# Altium schematic sheet Sheet3.SchDoc
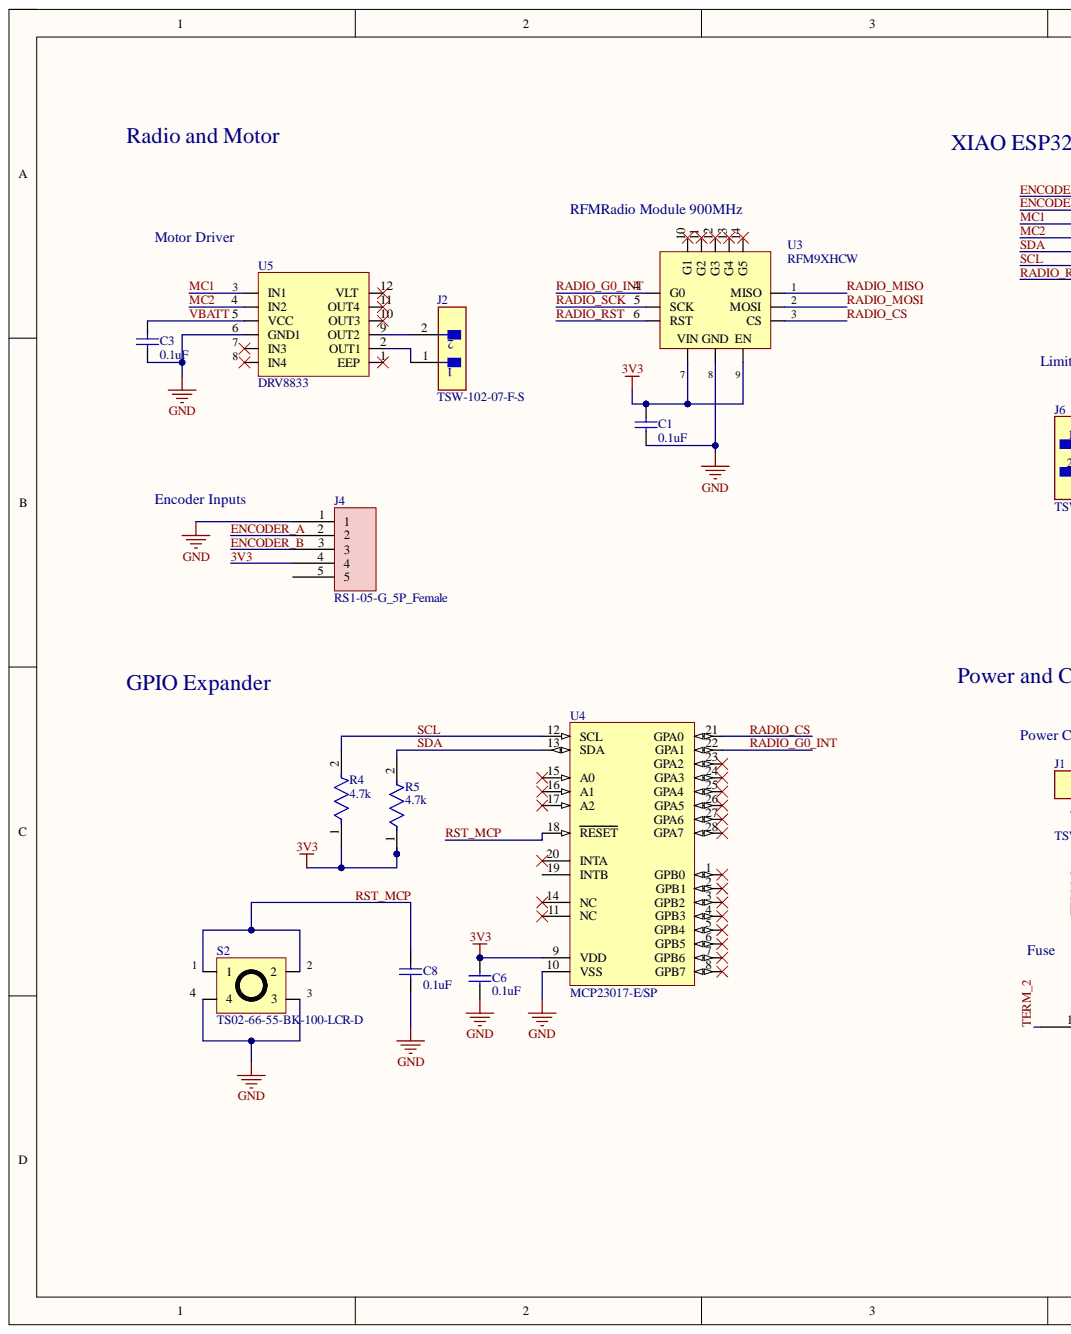
[diagram p0: Sheet3.SchDoc, left]
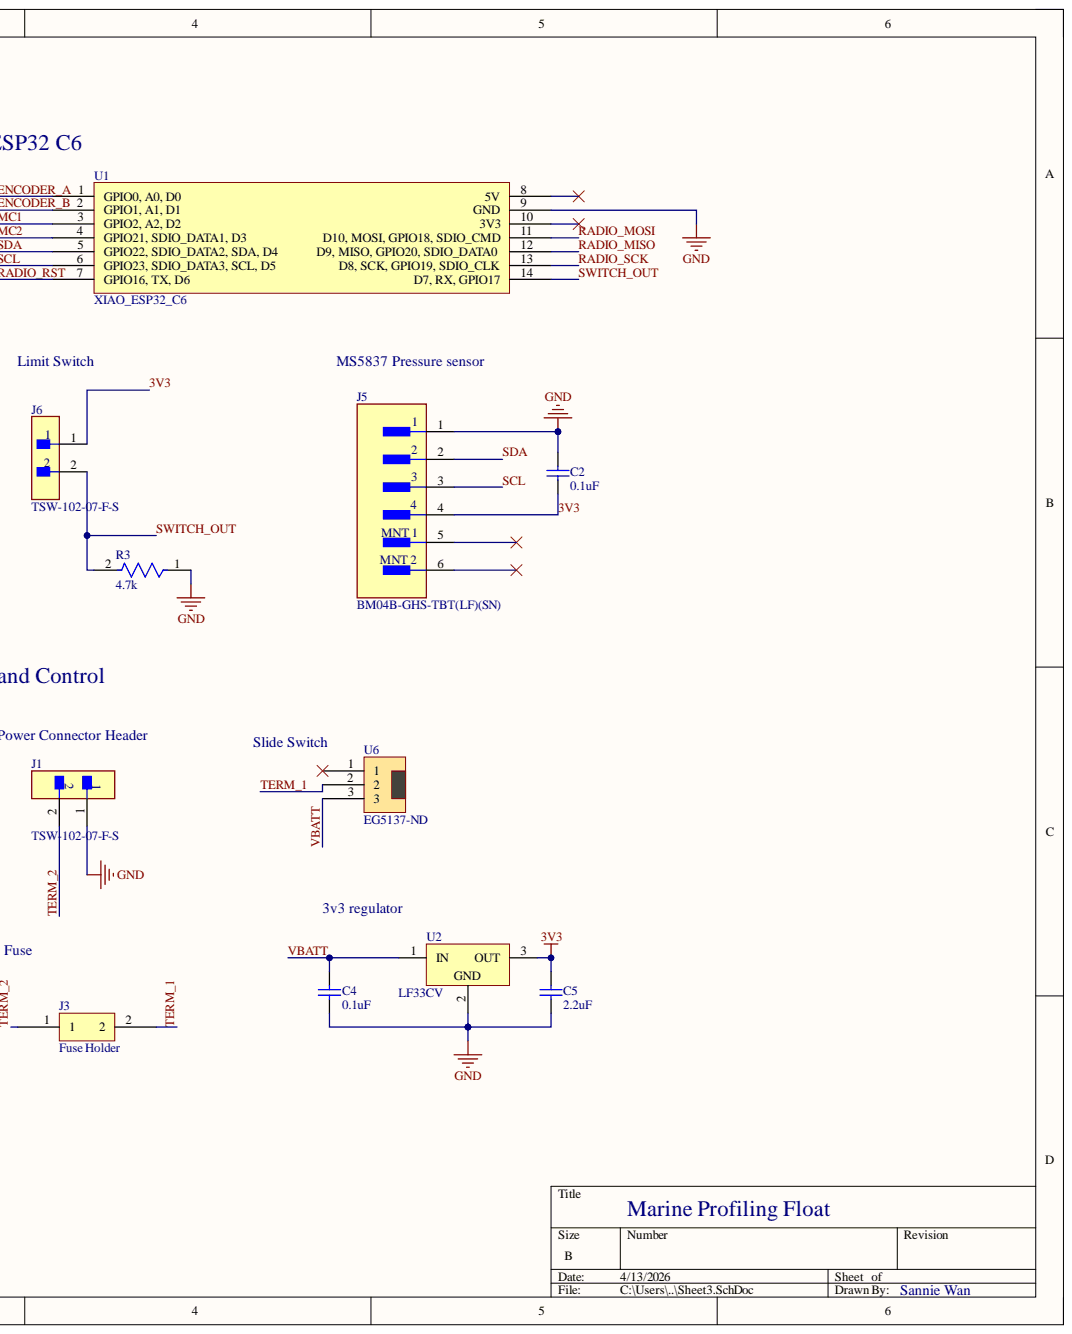
[diagram p1: Sheet3.SchDoc, right]

## Parts
C1  0.1uF  [CAPC0805(2012)100_M]
C2  0.1uF  [CAPC0805(2012)100_M]
C3  0.1uF  [CAPC0805(2012)100_M]
C4  0.1uF  [CAPC0805(2012)100_M]
C5  2.2uF  [CAPC2013X152X50ML20T25]
C6  0.1uF  [CAPC0805(2012)100_M]
C8  0.1uF  [CAPC0805(2012)100_M]
J1  TSW-102-07-F-S  Connector Type: Header  [FP-TSW-102-07-F-S-MFG]
J2  TSW-102-07-F-S  [FP-TSW-102-07-F-S-MFG]
J3  Fuse Holder  [Fuse-Holder-01110501Z]
J4  RS1-05-G_5P_Female  [TE_640456-7]
J5  BM04B-GHS-TBT(LF)(SN)  [FP-BM04B-GHS-TBT_LF_SN-MFG]
J6  TSW-102-07-F-S  [FP-TSW-102-07-F-S-MFG]
R3  4.7k  [FP-RCMM02-MFG]
R4  4.7k  [FP-RCMM02-MFG]
R5  4.7k  [FP-RCMM02-MFG]
S2  TS02-66-55-BK-100-LCR-D
U1  XIAO_ESP32_C6  [MODULE_XIAO_ESP32C6]
U2  LF33CV  [TO220]
U3  RFM9XHCW  [RFM9X_LoRa]
U4  MCP23017-E/SP  [SPDIP300-SP28]
U5  DRV8833
U6  EG5137-ND  [SW_500SSP1S2M2QEA]

## Nets
GND: C1.1 C2.2 C3.1 C4.1 C5.1 C6.1 C8.1 J1.1 J4.1 J5.1 R3.1 S2.3 S2.4 U1.9(GND) U2.2 U3.8 U4.10(VSS) U5.6(GND1)
3V3: C1.2 C2.1 C5.2 C6.2 J4.4 J5.4 J6.1 R4.1 R5.1 U2.3 U3.7 U3.9(EN) U4.9(VDD)
ENCODER_A: J4.2 U1.1(GPIO0,_A0,_D0)
ENCODER_B: J4.3 U1.2(GPIO1,_A1,_D1)
MC1: U1.3(GPIO2,_A2,_D2) U5.3(IN1)
MC2: U1.4(GPIO21,_SDIO_DATA1,_D3) U5.4(IN2)
RADIO_CS: U3.3(CS) U4.21(GPA0)
RADIO_G0_INT: U3.4(G0) U4.22(GPA1)
RADIO_MISO: U1.12(D9,_MISO,_GPIO20,_SDIO_DATA0) U3.1(MISO)
RADIO_MOSI: U1.11(D10,_MOSI,_GPIO18,_SDIO_CMD) U3.2(MOSI)
RADIO_RST: U1.7(GPIO16,_TX,_D6) U3.6(RST)
RADIO_SCK: U1.13(D8,_SCK,_GPIO19,_SDIO_CLK) U3.5(SCK)
RST_MCP: C8.2 S2.1 S2.2 U4.18(RESET)
SCL: J5.3 R4.2 U1.6(GPIO23,_SDIO_DATA3,_SCL,_D5) U4.12(SCL)
SDA: J5.2 R5.2 U1.5(GPIO22,_SDIO_DATA2,_SDA,_D4) U4.13(SDA)
SWITCH_OUT: J6.2 R3.2 U1.14(D7,_RX,_GPIO17)
TERM_1: J3.2 U6.2
TERM_2: J1.2 J3.1
VBATT: C3.2 C4.2 U2.1 U5.5(VCC) U6.3
NetJ2_1: J2.1 U5.2(OUT1)
NetJ2_2: J2.2 U5.9(OUT2)

Not connected: J4 5; J5 5 6; U1 8(5V) 10(3V3); U3 10(G1) 11(G2) 12(G3) 13(G4) 14(G5); U4 1(GPB0) 2(GPB1) 3(GPB2) 4(GPB3) 5(GPB4) 6(GPB5) 7(GPB6) 8(GPB7) 11(NC) 14(NC) 15(A0) 16(A1) 17(A2) 19(INTB) 20(INTA) 23(GPA2) 24(GPA3) 25(GPA4) 26(GPA5) 27(GPA6) 28(GPA7); U5 1(EEP) 7(IN3) 8(IN4) 10(OUT3) 11(OUT4) 12(VLT); U6 1

## Library data of the parts
C1-C4 C6 C8 = CMP-1036-04393-1: CAP 100nF 10V ±5% 0805 (2012 Metric) Thickness 1mm SMD; Case-EIA: 0805; Case-Metric: 2012; Tolerance: Â±5%; Component Kind: Standard; Library Name: Altium Content Vault; Max Thickness: 1 mm; Rated Voltage: 10 V; Technology: SMT; Value: 100nF
C5 = CMP-2007-04515-1: Manufacturer: AVX Interconnect / Elco; Part Number: 08053C225MAT2A; Component Kind: Standard; Library Name: Altium Content Vault
J1 J2 J6 = CMP-02766-001458-1: CONN HEADER VERT 2POS 2.54MM; Gender: Male; Max Current Rating: 7.6A; Max Voltage Rating (AC): 550V; Number of Contacts: 2; Color: Black; Component Kind: Standard; Contact Finish - Mating: GOLD; Contact Material: Bronze; Contact Mating Length: 0.23inch; Contact Plating: Gold; Contact Shape: Square; Contact Type: Male Pin; Depth: 2.54mm; Fastening Type: Pull; Flammability Rating: UL94 V-0; Housing Color: Black; Housing Material: Polyester; Insulation Color: Black; Insulation Material: PBT; Length: 5.08mm; Library Name: Altium Content Vault; Max Operating Temperature: 125°C; Min Operating Temperature: -55°C; Mount: Through Hole; Number of Positions: 2; Number of Rows: 1; Orientation: Straight; Package Quantity: 1; Packaging: Bulk; Pitch: 2.54mm; Radiation Hardening: No; REACH SVHC: No SVHC; RoHS Compliant: Yes; Series: TSW; Termination: Solder
  J2 J6: Connector Type: Header
J3 = Fuse_Holder: Component Kind: Standard; Library Name: Schlib1.SchLib
J4 = RS1-05-G: Connector, Receptacle Strip, 5 Position, 2.54MM Pitch, Single Row; Manufacturer: Adam Tech; Contact Resistance: 20mΩ; Current Rating: 3A; Insulation Resistance: 5GΩ; Category: Card Edge Connectors; Component Kind: Standard; ComponentLink1Description: https://datasheet.octopart.com:443/RS1-05-G-Adam-Tech-datasheet-65807175.pdf?src-supplier=IHS; ComponentLink1URL: https://datasheet.octopart.com:443/RS1-05-G-Adam-Tech-datasheet-65807175.pdf?src-supplier=IHS; ComponentLink2Description: http://sigma.octopart.com:80/reach_statement/Adam-Tech-company-23.pdf?src-supplier=Compliance+Statements; ComponentLink2URL: http://sigma.octopart.com:80/reach_statement/Adam-Tech-company-23.pdf?src-supplier=Compliance+Statements; ComponentLink3Description: http://sigma.octopart.com:80/rohs_statement/Adam-Tech-company-22.pdf?src-supplier=Compliance+Statements; ComponentLink3URL: http://sigma.octopart.com:80/rohs_statement/Adam-Tech-company-22.pdf?src-supplier=Compliance+Statements; Contact Gender: Female; Export Control Classification Number (ECCN) Code: EAR99; Harmonized Tariff Schedule (HTS) Code: 8536.69.40.40; Introduction Date: 2005-04-14; Library Name: Schlib1.SchLib; Lifecycle Status: Production; Manufacturer Part Number: RS1-05-G; Max Operating Temperature: 105°C; Min Operating Temperature: -40°C; Number of Rows: 1; Pins: 5; Pitch: 2.54mm; Pricing: 1=0.31, 10=0.264, 100=0.2239, 500=0.19986, 3000=0.17609, 6000=0.16769, 12000=0.15968 (USD); REACH SVHC: Yes; RoHS: Compliant; Stock: 5746; Supplier: DigiKey; Supplier Part Number: 2057-RS1-05-G-ND; Terminal Pitch: 2.54mm; Termination: PCB
J5 = CMP-17439-000062-2: CONN HEADER SMD 4POS 1.25MM; Current Rating: 1A; Gender: Male; Number of Contacts: 4; Voltage Rating: 50V; Wire/Cable Gauge: 26AWG; Case/Package: SMD/SMT; Component Kind: Standard; Contact Material: Bronze; Contact Plating: Tin; Housing Color: Natural; Housing Material: Nylon; Lead Free: Lead Free; Library Name: Altium Content Vault; Max Operating Temperature: 85°C; Min Operating Temperature: -25°C; Number of Positions: 4; Number of Rows: 4; Orientation: Straight; Packaging: Cut Tape; Pitch: 1.25mm; REACH SVHC: No SVHC; Series: GH; Termination: Crimp; Voltage Rating (AC): 50V
R3-R5 = CMP-02408-000034-1: RES 4.7K OHM 2% 1/2W AXIAL; Resistance: 4.7kR; Temperature Coefficient: 100ppm/°C; Case/Package: Molded; Component Kind: Standard; Contact Plating: Tin; Diameter: 2.5mm; Length: 6.5mm; Library Name: Altium Content Vault; Mount: Through Hole; Resistor Type: Metal Film; RoHS Compliant: Yes; Tolerance: 2%
S2 = TS02-66-55-BK-100-LCR-D: Switch Tactile OFF (ON) SPST Round Button PC Pins 0.05A 12VDC 100000Cycles 1N Thru-Hole Bag; Manufacturer: Same Sky; Contact Resistance: 100mΩ; Electrical Life: 100kcycles; Max Current Rating: 50mA; Max Voltage Rating (DC): 12V; Number of Positions: 1; Operating Force: 980mN; Category: Tactile Switches; Color: Black; Component Kind: Standard; ComponentLink1Description: https://datasheet.octopart.com:443/TS02-66-55-BK-100-LCR-D-Same-Sky-datasheet-183540777.pdf?src-supplier=Same+Sky; ComponentLink1URL: https://datasheet.octopart.com:443/TS02-66-55-BK-100-LCR-D-Same-Sky-datasheet-183540777.pdf?src-supplier=Same+Sky; ComponentLink2Description: https://datasheet.octopart.com:443/TS02-66-55-BK-100-LCR-D-Same-Sky-datasheet-181920466.pdf?src-supplier=Same+Sky; ComponentLink2URL: https://datasheet.octopart.com:443/TS02-66-55-BK-100-LCR-D-Same-Sky-datasheet-181920466.pdf?src-supplier=Same+Sky; ComponentLink3Description: https://datasheet.octopart.com:443/TS02-66-55-BK-100-LCR-D-Same-Sky-datasheet-181920465.pdf?src-supplier=Same+Sky; ComponentLink3URL: https://datasheet.octopart.com:443/TS02-66-55-BK-100-LCR-D-Same-Sky-datasheet-181920465.pdf?src-supplier=Same+Sky; ComponentLink4Description: https://datasheet.octopart.com:443/TS02-66-55-BK-100-LCR-D-CUI-Devices-datasheet-175928973.pdf?src-supplier=Same+Sky; ComponentLink4URL: https://datasheet.octopart.com:443/TS02-66-55-BK-100-LCR-D-CUI-Devices-datasheet-175928973.pdf?src-supplier=Same+Sky; ComponentLink5Description: https://datasheet.octopart.com:443/TS02-66-55-BK-100-LCR-D-CUI-Devices-datasheet-155929901.pdf?src-supplier=Same+Sky; ComponentLink5URL: https://datasheet.octopart.com:443/TS02-66-55-BK-100-LCR-D-CUI-Devices-datasheet-155929901.pdf?src-supplier=Same+Sky; ComponentLink6Description: https://datasheet.octopart.com:443/TS02-66-55-BK-100-LCR-D-CUI-Devices-datasheet-180438921.pdf?src-supplier=IHS; ComponentLink6URL: https://datasheet.octopart.com:443/TS02-66-55-BK-100-LCR-D-CUI-Devices-datasheet-180438921.pdf?src-supplier=IHS; ComponentLink7Description: https://datasheet.octopart.com:443/TS02-66-55-BK-100-LCR-D-Same-Sky-datasheet-192884239.pdf?src-supplier=Farnell; ComponentLink7URL: https://datasheet.octopart.com:443/TS02-66-55-BK-100-LCR-D-Same-Sky-datasheet-192884239.pdf?src-supplier=Farnell; ComponentLink8Description: https://datasheet.octopart.com:443/TS02-66-55-BK-100-LCR-D-Same-Sky-datasheet-182881588.pdf?src-supplier=Electro+Sonic; ComponentLink8URL: https://datasheet.octopart.com:443/TS02-66-55-BK-100-LCR-D-Same-Sky-datasheet-182881588.pdf?src-supplier=Electro+Sonic; ComponentLink9Description: https://datasheet.octopart.com:443/TS02-66-55-BK-100-LCR-D-Same-Sky-datasheet-189105763.pdf?src-supplier=Master+Electronics; ComponentLink9URL: https://datasheet.octopart.com:443/TS02-66-55-BK-100-LCR-D-Same-Sky-datasheet-189105763.pdf?src-supplier=Master+Electronics; ComponentLink10Description: https://datasheet.octopart.com:443/TS02-66-55-BK-100-LCR-D-Same-Sky-datasheet-188930957.pdf?src-supplier=TME; ComponentLink10URL: https://datasheet.octopart.com:443/TS02-66-55-BK-100-LCR-D-Same-Sky-datasheet-188930957.pdf?src-supplier=TME; ComponentLink11Description: https://octopart.com:443/opatz8j6/c1?t=OsniuKHIxL9eaTBNKFdmsngdbw6MfabXil4KJ5swV2Ol6SwHTbl3DtBshbTx_WjDJtfmbYFgBopMi8e_4EfEujrCRZkkImDpeSqwCkgMsDWzywFcqvkdfRE6i5nDJAdPo3NHL2kAbPl3Km36jQCVtG2LbZcPKjMZASa3gurvGypvOQ2PXpRslTybkNNKdlulmXRsPenlHsAoJ9UDohdkFhwYygl9PlDny3RCi9M1uZlEU0OHHNyMO7n3cv4R3WpjLcVkDxCFrI2_MmjbsxwjvJZiihq0K3HwcGB2UdclHrzu-x8wwC3CJuv5FbISYTtR3fwYpZVa4dkoGSvHFm9qgSbk2u_HZq074TibVvXQUrWZs1n-JzFjaPg&src-supplier=Compliance+Statements; ComponentLink11URL: https://octopart.com:443/opatz8j6/c1?t=OsniuKHIxL9eaTBNKFdmsngdbw6MfabXil4KJ5swV2Ol6SwHTbl3DtBshbTx_WjDJtfmbYFgBopMi8e_4EfEujrCRZkkImDpeSqwCkgMsDWzywFcqvkdfRE6i5nDJAdPo3NHL2kAbPl3Km36jQCVtG2LbZcPKjMZASa3gurvGypvOQ2PXpRslTybkNNKdlulmXRsPenlHsAoJ9UDohdkFhwYygl9PlDny3RCi9M1uZlEU0OHHNyMO7n3cv4R3WpjLcVkDxCFrI2_MmjbsxwjvJZiihq0K3HwcGB2UdclHrzu-x8wwC3CJuv5FbISYTtR3fwYpZVa4dkoGSvHFm9qgSbk2u_HZq074TibVvXQUrWZs1n-JzFjaPg&src-supplier=Compliance+Statements; ComponentLink12Description: https://octopart.com:443/opatz8j6/c1?t=JAh-5wnsDEFx0xwTAvLyG-kVzhXkoZ8KXl8Zn4v9obtJjaoVyYlA-HGTFHzOmHIjQYscAj70scO1cLgq90Ink22aiZ0sKJt2_I_X2gh4O10ZhMc_OjXRGmz_NwXoiXp5b30DQniv0BJv46rs4CXBinQ771hT3TTID1OLSnjMj5iiWVXos29f76iZ_GD_UvTafB4o7NyWugk8DYHE9Cuo0aXLG5ASz4RDD0lYrD4hqTxV3tUJU2Vfy6sjCB0T2aDYG3-s5fiG_EknPHN_pNh6sSrR-C_b9xeWeUOBvjq4BQl3D6DwgHnYlXXNd1wvimBGxHbwPCDcm9-nrYE4koDzMxRDJls2f1FC4w&src-supplier=Compliance+Statements; ComponentLink12URL: https://octopart.com:443/opatz8j6/c1?t=JAh-5wnsDEFx0xwTAvLyG-kVzhXkoZ8KXl8Zn4v9obtJjaoVyYlA-HGTFHzOmHIjQYscAj70scO1cLgq90Ink22aiZ0sKJt2_I_X2gh4O10ZhMc_OjXRGmz_NwXoiXp5b30DQniv0BJv46rs4CXBinQ771hT3TTID1OLSnjMj5iiWVXos29f76iZ_GD_UvTafB4o7NyWugk8DYHE9Cuo0aXLG5ASz4RDD0lYrD4hqTxV3tUJU2Vfy6sjCB0T2aDYG3-s5fiG_EknPHN_pNh6sSrR-C_b9xeWeUOBvjq4BQl3D6DwgHnYlXXNd1wvimBGxHbwPCDcm9-nrYE4koDzMxRDJls2f1FC4w&src-supplier=Compliance+Statements; ComponentLink13Description: https://octopart.com:443/opatz8j6/c1?t=hnav-g1km1ua1_avUmhOK0Kx74qI78k-2sHHIGrwQMLykihXQ6yIL3GYut8q30BKntENEfL2UcviuTm8VPXvNa5JCRNWIw94dFs9-CMEbO5yfsvmeRro6vdolX-GRU92RRig-2VN1Zr2Pywzi9CIydqYev4S_HXAtgcwxze6ryQQh_SFUJl3l1MB7yxMp0CVOTCJ-gEU-_zP8Dyzs7abjsxqz80gS2uhN5TQxC9yRyg6CZ-7Fvk0xINCc3IneAFDMNa88MXKOoFe-c898v8TlvNAVAwuYd-lvHguATrXrnXZNrvjEw9BMer5RZY6-8G-TMtVNK7k68JJxTFlUmvYOPzCgjL2Qi0A3hANb1_EbAcsTDUpmg&src-supplier=Compliance+Statements; ComponentLink13URL: https://octopart.com:443/opatz8j6/c1?t=hnav-g1km1ua1_avUmhOK0Kx74qI78k-2sHHIGrwQMLykihXQ6yIL3GYut8q30BKntENEfL2UcviuTm8VPXvNa5JCRNWIw94dFs9-CMEbO5yfsvmeRro6vdolX-GRU92RRig-2VN1Zr2Pywzi9CIydqYev4S_HXAtgcwxze6ryQQh_SFUJl3l1MB7yxMp0CVOTCJ-gEU-_zP8Dyzs7abjsxqz80gS2uhN5TQxC9yRyg6CZ-7Fvk0xINCc3IneAFDMNa88MXKOoFe-c898v8TlvNAVAwuYd-lvHguATrXrnXZNrvjEw9BMer5RZY6-8G-TMtVNK7k68JJxTFlUmvYOPzCgjL2Qi0A3hANb1_EbAcsTDUpmg&src-supplier=Compliance+Statements; ComponentLink14Description: https://octopart.com:443/opatz8j6/c1?t=bor8mHRCuwhyku8KaEo4LmOYgx_ywmGJ4w7TfaaEWZPBnxFXar9XIxBO_ABIv7nfRtm98LdRpBxYxKPoIyuE26GaWzQz6aNPoDyvi2niF9wAbHQvj8-k8ooqlarfmHagj8cRXg_t23bDsC77kf5uqSywYyBHpnlMVtQiKPnrhEInCDl3mhVBtPmzJ2TfFIJxbEwmvUkG7yrzv4eB4TtNipw_jKA_MY8WicTCIsWO_XmgeV0eJk_7Z0qkxp8g8F1sbdzNnZS6VXSiK85h7yvH0daqQS2ELMbH7rttzkurRp-ChBDsS7rgSlob-_-Ap-uCmRlBS187NKfS6Vq6JjePOGvuFHtGfqla6effgQkoaS5ZkxvVsUuUBnY&src-supplier=Compliance+Statements; ComponentLink14URL: https://octopart.com:443/opatz8j6/c1?t=bor8mHRCuwhyku8KaEo4LmOYgx_ywmGJ4w7TfaaEWZPBnxFXar9XIxBO_ABIv7nfRtm98LdRpBxYxKPoIyuE26GaWzQz6aNPoDyvi2niF9wAbHQvj8-k8ooqlarfmHagj8cRXg_t23bDsC77kf5uqSywYyBHpnlMVtQiKPnrhEInCDl3mhVBtPmzJ2TfFIJxbEwmvUkG7yrzv4eB4TtNipw_jKA_MY8WicTCIsWO_XmgeV0eJk_7Z0qkxp8g8F1sbdzNnZS6VXSiK85h7yvH0daqQS2ELMbH7rttzkurRp-ChBDsS7rgSlob-_-Ap-uCmRlBS187NKfS6Vq6JjePOGvuFHtGfqla6effgQkoaS5ZkxvVsUuUBnY&src-supplier=Compliance+Statements; ComponentLink15Description: https://octopart.com:443/opatz8j6/c1?t=XeQOmNgCsVq9IPQT7zcWQbu5jicqZOgWlkSJhhZ0sE4F8Wa6meX16TsfOQiwY-6AZTUIZzZwwdZ0tt9jOrrBagAGHiwBfDm-xM7OY4oi9kNpuPp2a6hXC5Cubtjr9nP3XX996vIUBBA7g7X4Of1AVdJIHUuY69Kbljc1ZW2aSb9odR_adRto3dby_dwzR1gc0A7r00k5hAhiJu6mYJMiOCPF8SY1ZxNSOl7PuRqXRuchXoY08mMOgtFoTwBEVrhyPMacz1oSj97iEITSbi_f2HcKiFGXdvVRWfoTp7ATTSQH-ZGbojhXRIX1VJtjBBtgMEwIfWWqWXjpYEHcISRter5YjIaJI9Zg2w&src-supplier=Compliance+Statements; ComponentLink15URL: https://octopart.com:443/opatz8j6/c1?t=XeQOmNgCsVq9IPQT7zcWQbu5jicqZOgWlkSJhhZ0sE4F8Wa6meX16TsfOQiwY-6AZTUIZzZwwdZ0tt9jOrrBagAGHiwBfDm-xM7OY4oi9kNpuPp2a6hXC5Cubtjr9nP3XX996vIUBBA7g7X4Of1AVdJIHUuY69Kbljc1ZW2aSb9odR_adRto3dby_dwzR1gc0A7r00k5hAhiJu6mYJMiOCPF8SY1ZxNSOl7PuRqXRuchXoY08mMOgtFoTwBEVrhyPMacz1oSj97iEITSbi_f2HcKiFGXdvVRWfoTp7ATTSQH-ZGbojhXRIX1VJtjBBtgMEwIfWWqWXjpYEHcISRter5YjIaJI9Zg2w&src-supplier=Compliance+Statements; ComponentLink16Description: https://octopart.com:443/opatz8j6/c1?t=9DeHlhxn3JPyLHfy3VWkIydLhlwabqFbNODhx6xtRJJGrAmqTDoZDsZ9X1C-iRq09zBgjbHMf9dWxuCVu81gGskX8p5QWBdDEmgeRI5L9uxdPh9kKj6DrIi6Zst1BNM3xhoD9Q61h4k2EzU7qwyEjxfNk-DrYCv50NV-Q1rXCMmtT2q1BSQzqt4X7aeLZJXE34XOX94Ssc8szaqGhhBxSGgAw7X_UqS8dN2vbcwrBWZOhaCzlfRuwKYP8zh21o8AZA8UFeFqa8BD88-hzGLW_I7qJZnDwS2pd9FFd94V23VdrHoULmY5sX9_Lo2K8mcQW3jOtXoHld3Tu274gNuCF89W7P9jl6MP7Kan4UgpwS33jy47nA&src-supplier=Compliance+Statements; ComponentLink16URL: https://octopart.com:443/opatz8j6/c1?t=9DeHlhxn3JPyLHfy3VWkIydLhlwabqFbNODhx6xtRJJGrAmqTDoZDsZ9X1C-iRq09zBgjbHMf9dWxuCVu81gGskX8p5QWBdDEmgeRI5L9uxdPh9kKj6DrIi6Zst1BNM3xhoD9Q61h4k2EzU7qwyEjxfNk-DrYCv50NV-Q1rXCMmtT2q1BSQzqt4X7aeLZJXE34XOX94Ssc8szaqGhhBxSGgAw7X_UqS8dN2vbcwrBWZOhaCzlfRuwKYP8zh21o8AZA8UFeFqa8BD88-hzGLW_I7qJZnDwS2pd9FFd94V23VdrHoULmY5sX9_Lo2K8mcQW3jOtXoHld3Tu274gNuCF89W7P9jl6MP7Kan4UgpwS33jy47nA&src-supplier=Compliance+Statements; Contact Plating: Silver; Export Control Classification Number (ECCN) Code: EAR99; Harmonized Tariff Schedule (HTS) Code: 8536.50.90.40; Height: 3.5mm; Housing Material: Stainless Steel; Insulation Resistance: 100MΩ; Introduction Date: 2021-10-07; Length: 6mm; Library Name: Schlib1.SchLib; Lifecycle Status: Production; Manufacturer Part Number: TS02-66-55-BK-100-LCR-D; Max Operating Temperature: 80°C; Min Operating Temperature: -30°C; Pricing: 1=0.11, 10=0.098, 25=0.0924, 100=0.0833, 250=0.0774, 500=0.07308, 1000=0.06896, 3000=0.06295, 5000=0.06041 (USD); REACH SVHC: Yes; RoHS: Compliant; Schedule B: 8536509030, 8536509065, 8536509065|8536509065, 8536509065|8536509065|8536509065; Stock: 4018; Supplier: DigiKey; Supplier Part Number: 2223-TS02-66-55-BK-100-LCR-D-ND; Terminal Material: Brass; Throw Configuration: SPST
U1 = XIAO_ESP32_C6: seeed studio FCC ID:Z4T-XIAOESP32C6; Component Kind: Standard; Library Name: Schlib1.SchLib
U2 = CMP-0244-00432-1: 500mA, Very Low Drop (0.45V) Voltage Regulator, 3.3V, 3-Pin TO-220; Component Kind: Standard; ComponentLink1Description: Manufacturer URL; ComponentLink1URL: http://www.st.com; ComponentLink2Description: Datasheet; ComponentLink2URL: http://www.st.com/internet/com/TECHNICAL_RESOURCES/TECHNICAL_LITERATURE/DATASHEET/CD00000546.pdf; ComponentLink3Description: Package Specification; ComponentLink3URL: http://www.st.com/internet/com/TECHNICAL_RESOURCES/TECHNICAL_LITERATURE/PACKAGE_INFORMATION/CD00004649.pdf; DatasheetVersion: 1/11/2010; Library Name: Altium Content Vault; PackageDescription: TO, Thru-Hole, Vertical, Heatsink Mounted; 3 In-Line Leads; Pitch 2.54 mm; PackageReference: TO220; PackageVersion: ver3.0
U3 = RFM9XHCW: Adafruit Radio Module; Component Kind: Standard; Library Name: Schlib1.SchLib
U4 = CMP-0186-00081-2: 16-Bit I/O Expander with Serial Interface, 28-Pin SPDIP, Extended Temperature; Component Kind: Standard; ComponentLink1Description: Manufacturer URL; ComponentLink1URL: http://www.microchip.com/; ComponentLink2Description: Datasheet; ComponentLink2URL: http://ww1.microchip.com/downloads/en/DeviceDoc/21952b.pdf; ComponentLink3Description: Package Specification; ComponentLink3URL: http://www.microchip.com/stellent/groups/techpub_sg/documents/packagingspec/en012702.pdf; DatasheetVersion: revB, Feb-2007; Library Name: Altium Content Vault; PackageDescription: 28-Lead Skinny Plastic Dual In-Line (SP) - 300 mil Body [SPDIP]; PackageReference: SPDIP300-SP28; PackageVersion: revBB, Aug-2009; RevisionNote: URLs added.
U5 = DRV8833: Motor Driver 6.5V-45VDC In; Component Kind: Standard; Library Name: Schlib1.SchLib
U6 = 500SSP1S2M2QEA: Switch Slide ON None ON SPDT Extended Top Slide 5A 250VAC 28VDC PC Pins Thru-Hole; Manufacturer: E-Switch; Actuator Length: 7.239mm; Actuator Material: Nylon; Contact Current Rating: 2A; Contact Resistance: 10mΩ; Contact Voltage Rating (AC): 250V; Electrical Life: 30kcycles; Insulation Resistance: 1GΩ; Max Voltage Rating (DC): 28V; Number of Positions: 1; Sealable: EPOXY; Switch Function: On-On; Switch Travel: 2.16mm; Voltage Rating (AC): 120V; Category: Slide Switches; Component Kind: Standard; ComponentLink1Description: https://datasheet.octopart.com:443/500SSP1S2M2QEA-E-Switch-datasheet-41192586.pdf?src-supplier=element14+APAC; ComponentLink1URL: https://datasheet.octopart.com:443/500SSP1S2M2QEA-E-Switch-datasheet-41192586.pdf?src-supplier=element14+APAC; ComponentLink2Description: https://datasheet.octopart.com:443/500SSP1S2M2QEA-E-Switch-datasheet-156105959.pdf?src-supplier=Future+Electronics; ComponentLink2URL: https://datasheet.octopart.com:443/500SSP1S2M2QEA-E-Switch-datasheet-156105959.pdf?src-supplier=Future+Electronics; ComponentLink3Description: https://datasheet.octopart.com:443/500SSP1S2M2QEA-E-Switch-datasheet-169364037.pdf?src-supplier=New+Yorker+Electronics; ComponentLink3URL: https://datasheet.octopart.com:443/500SSP1S2M2QEA-E-Switch-datasheet-169364037.pdf?src-supplier=New+Yorker+Electronics; ComponentLink4Description: https://datasheet.octopart.com:443/500SSP1S2M2QEA-E-Switch-datasheet-33408909.pdf?src-supplier=Electro+Sonic; ComponentLink4URL: https://datasheet.octopart.com:443/500SSP1S2M2QEA-E-Switch-datasheet-33408909.pdf?src-supplier=Electro+Sonic; Contact Material: Copper; Contact Plating: Silver; Current Rating: 5A; Export Control Classification Number (ECCN) Code: EAR99; Harmonized Tariff Schedule (HTS) Code: 8536.50.70.00; Housing Material: Stainless Steel; Introduction Date: 2000-02-16; Length: 12.8524mm; Library Name: Schlib1.SchLib; Lifecycle Status: Production; Manufacturer Part Number: 500SSP1S2M2QEA; Max Current Rating: 5A; Max Operating Temperature: 85°C; Mechanical Life: 30kcycles; Min Operating Temperature: -30°C; Mounting Technology: Through Hole; Orientation: Vertical; Pricing: 1=3.29, 10=2.758, 25=2.556, 126=2.23468, 252=2.11369, 504=2.00373, 1008=1.90486, 2520=1.79075, 5040=1.71628 (USD); REACH SVHC: Yes; RoHS: Compliant; Stock: 7980; Supplier: DigiKey; Supplier Part Number: EG5137-ND; Termination: PC Pin; Throw Configuration: SPDT; Voltage Rating (DC): 28V
